# Altium schematic sheet CAMERA.SchDoc
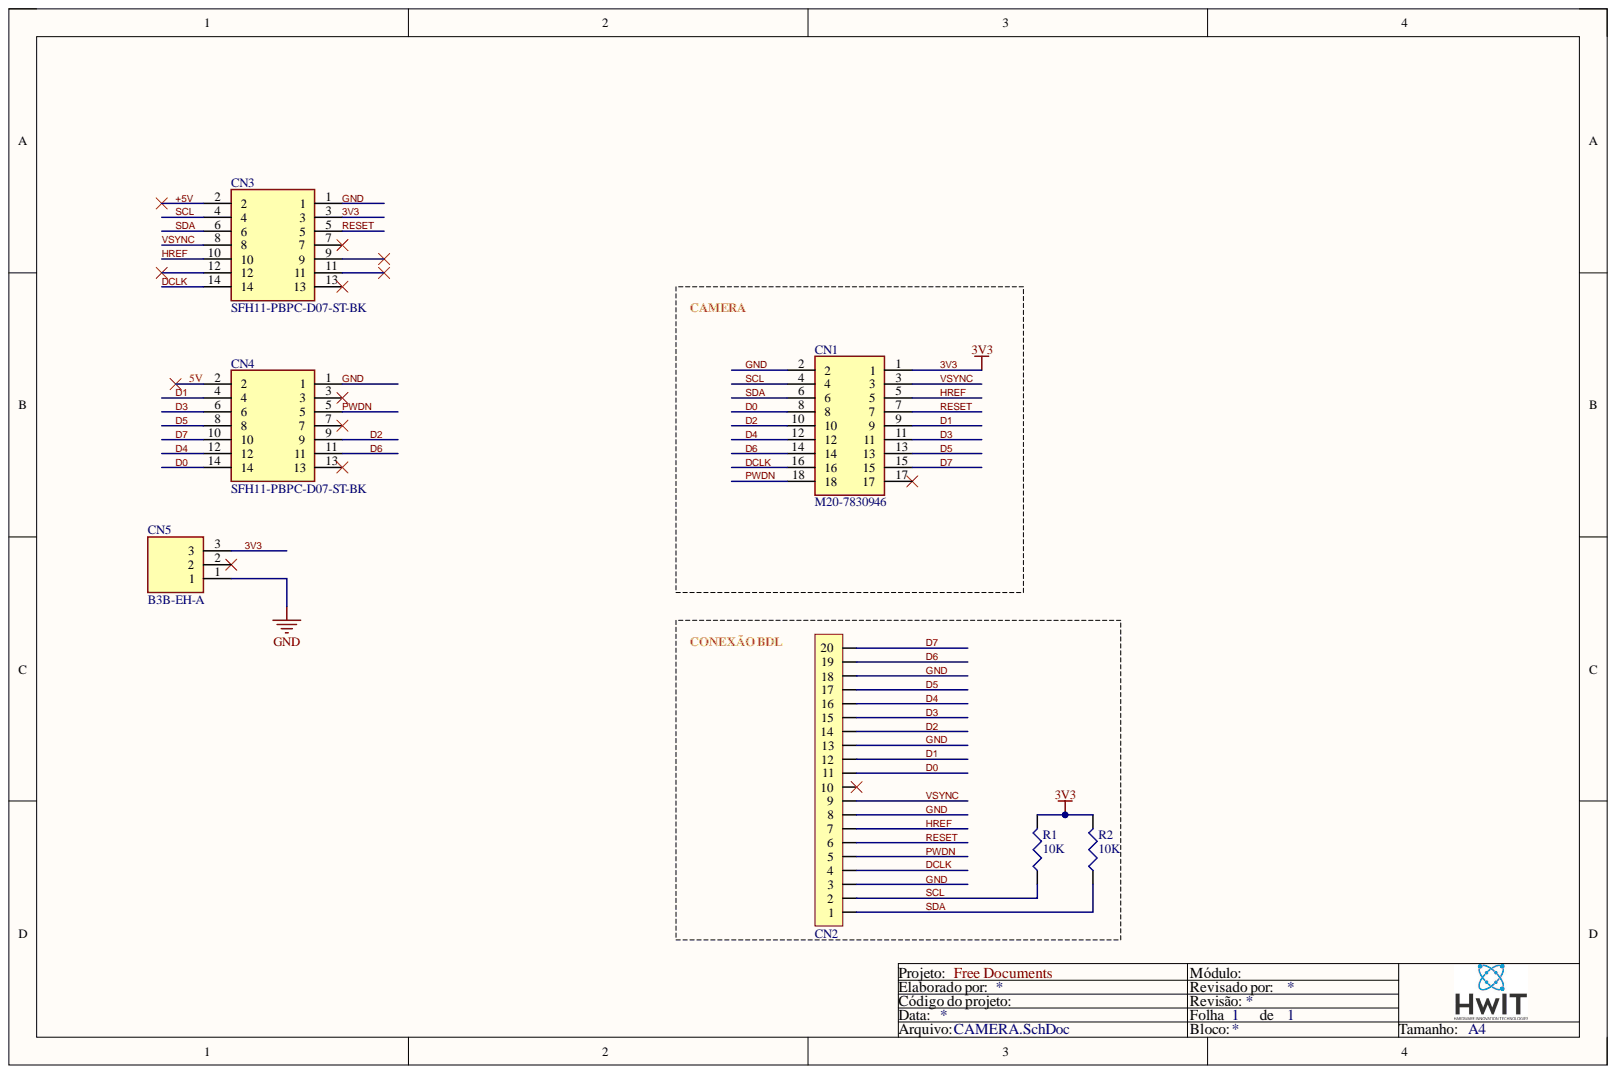
[diagram p0: CAMERA.SchDoc]

## Parts
CN1  M20-7830946  [RHDR18W70P254_2X9_2326X504X865P]
CN2  HD 1X20 VERT  [HEADER 20 VERT 2.54mm]  (value not drawn)
CN3  SFH11-PBPC-D07-ST-BK  [SULLINS_SFH11-PBPC-D07-ST-BK]
CN4  SFH11-PBPC-D07-ST-BK  [SULLINS_SFH11-PBPC-D07-ST-BK]
CN5  B3B-EH-A  [SHDR3W64P0X250_1X3_1000X380X600P]
R1  10K  [0603R-RAW]
R2  10K  [0603R-RAW]

## Nets
3V3: CN1.1 CN3.3 CN5.3 R1.2 R2.2
+5V: CN3.2
D0: CN1.8 CN2.11 CN4.14
D1: CN1.9 CN2.12 CN4.4
D2: CN1.10 CN2.14 CN4.9
D3: CN1.11 CN2.15 CN4.6
D4: CN1.12 CN2.16 CN4.12
D5: CN1.13 CN2.17 CN4.8
D6: CN1.14 CN2.19 CN4.11
D7: CN1.15 CN2.20 CN4.10
DCLK: CN1.16 CN2.4 CN3.14
GND: CN1.2 CN2.3 CN2.8 CN2.13 CN2.18 CN3.1 CN4.1 CN5.1
HREF: CN1.5 CN2.7 CN3.10
PWDN: CN1.18 CN2.5 CN4.5
RESET: CN1.7 CN2.6 CN3.5
SCL: CN1.4 CN2.2 CN3.4 R1.1
SDA: CN1.6 CN2.1 CN3.6 R2.1
VSYNC: CN1.3 CN2.9 CN3.8

Not connected: CN1 17; CN2 10; CN3 7 9 11 12 13; CN4 2 3 7 13; CN5 2

## Library data of the parts
CN1 = M20-7830946: CONN RCPT 18POS 0.1 TIN PCB; Manufacturer: Harwin; Component Kind: Standard; Datasheet Link: https://cdn.harwin.com/pdfs/M20-783.pdf; Height: 8.65mm; Library Name: SamacSys.SchLib; Manufacturer PN: M20-7830946; Mouser Part Number: 855-M20-7830946; Mouser Price/Stock: https://www.mouser.co.uk/ProductDetail/Harwin/M20-7830946?qs=Jph8NoUxIfWftUxGO%2FckBg%3D%3D
CN2 = HEADER 1x20 V 2.54MM: CONN HEADER 1x20 V 2.54MM; Manufacturer: Adam Tech; Manufacturer PN: PH1-20-UA; Component Kind: Standard; Library Name: Conectores.SchLib
CN3 CN4 = SFH11-PBPC-D07-ST-BK: 14 Position Header Connector Through Hole; Manufacturer: Sullins Connector; Availability: Warning; Check_prices: https://www.snapeda.com/parts/SFH11-PBPC-D07-ST-BK/Sullins%20Connector%20Solutions/view-part/851415/?ref=eda; Component Kind: Standard; Library Name: Conectores.SchLib; Manufacturer PN: SFH11-PBPC-D07-ST-BK; Package: None; Price: None; Purchase-URL: https://pricing.snapeda.com/search/part/SFH11-PBPC-D07-ST-BK/?ref=eda; SnapEDA_Link: https://www.snapeda.com/parts/SFH11-PBPC-D07-ST-BK/Sullins%20Connector%20Solutions/view-part/851415/?ref=snap
CN5 = B3B-EH-A: Connector; Manufacturer_Name: JST (JAPAN SOLDERLESS TERMINALS); Component Kind: Standard; Datasheet Link: https://datasheet.datasheetarchive.com/originals/dk/DKDS-2/32954.pdf; Height: 6mm; Manufacturer_Part_Number: B3B-EH-A
R1 R2 = __ R 0603 __ %: RES SMD 10K OHM 5% 1/10W 0603; Manufacturer: YAGEO; Manufacturer Part Number: RC0603FR-0710KL; Component Kind: Standard; Digi-Key Part Number: 311-10.0KHRDKR-ND; Mouser Part Number: 603-RC0603FR-0710KL; Library Name: Resistores.SchLib
